# Altium schematic sheet HLib-Comm-Ether_r1.SchDoc(HLib-Comm-Ether_r1)
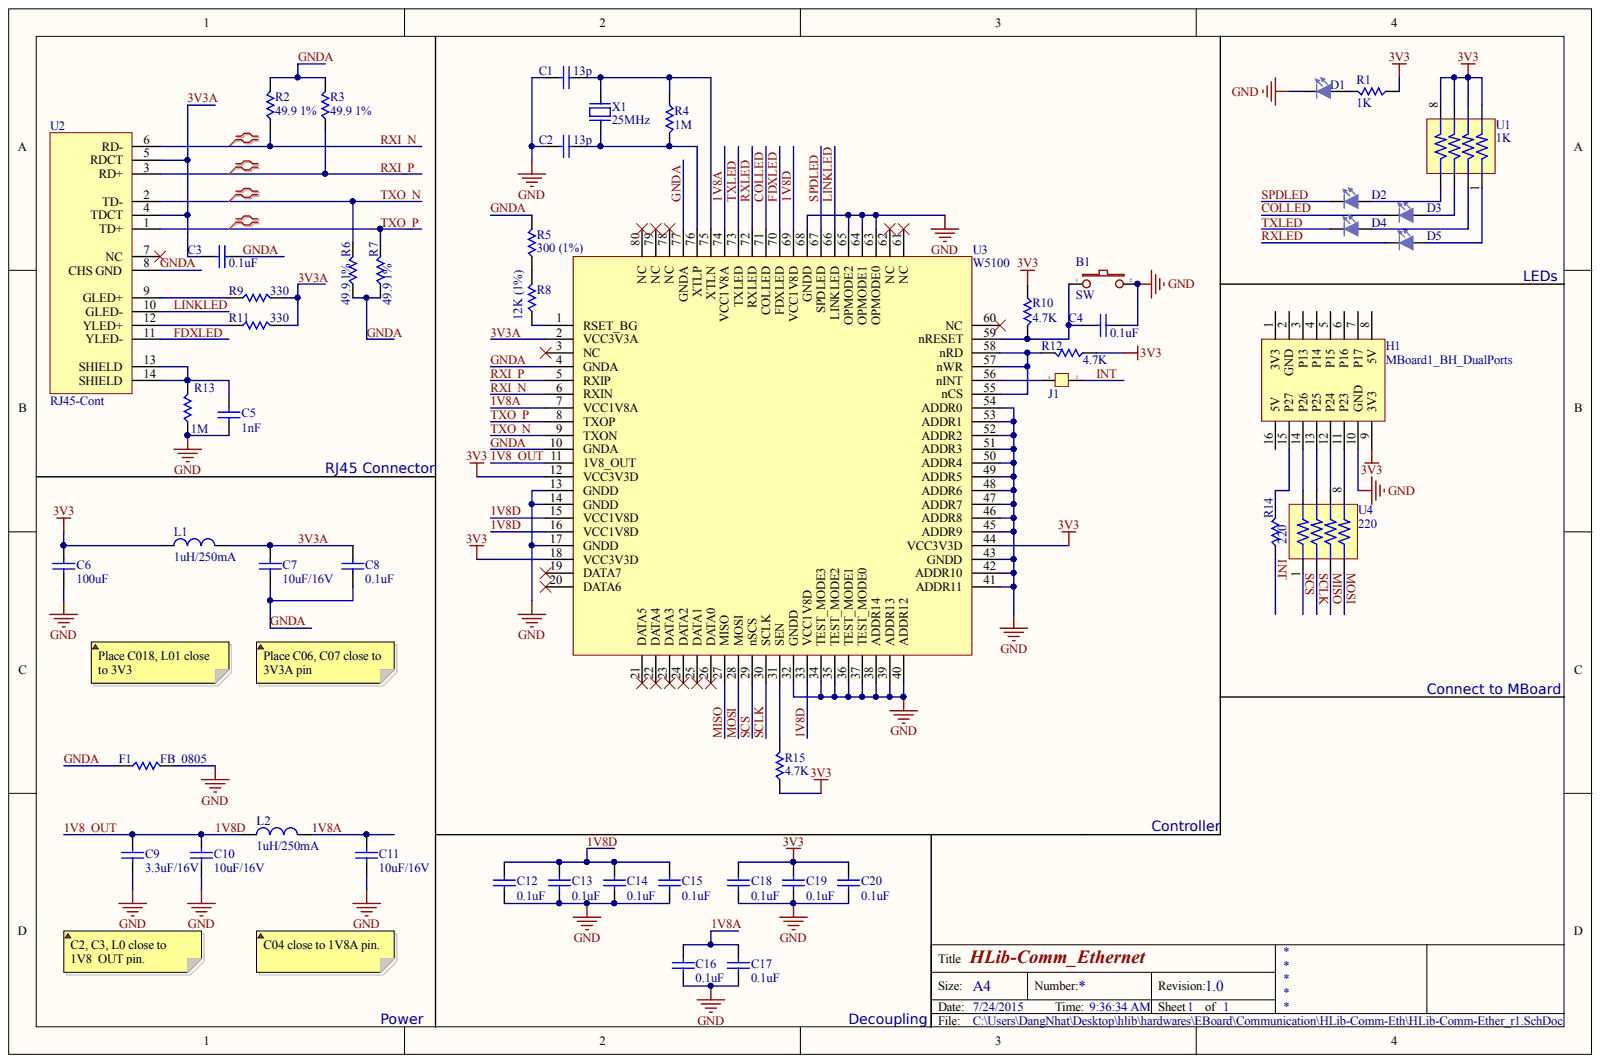
[diagram p0: HLib-Comm-Ether_r1.SchDoc]

## Parts
B1  SW  [SW2SMD]
C1  value 13p  [SM_0805_C_CERAMIC]  (hidden comment: C_Ceramic)
C2  value 13p  [SM_0805_C_CERAMIC]  (hidden comment: C_Ceramic)
C3  value 0.1uF  [SM_0805_C_CERAMIC]  (hidden comment: C_Ceramic)
C4  value 0.1uF  [SM_0805_C_CERAMIC]  (hidden comment: C_Ceramic)
C5  value 1nF  [SM_0805_C_CERAMIC]  (hidden comment: C_Ceramic)
C6  value 100uF  [Cap-Alu-D8]  (hidden comment: C_Ceramic)
C7  value 10uF/16V  [SM_0805_C_CERAMIC]  (hidden comment: C_Ceramic)
C8  value 0.1uF  [SM_0805_C_CERAMIC]  (hidden comment: C_Ceramic)
C9  value 3.3uF/16V  [SM_0805_C_CERAMIC]  (hidden comment: C_Ceramic)
C10  value 10uF/16V  [SM_0805_C_CERAMIC]  (hidden comment: C_Ceramic)
C11  value 10uF/16V  [SM_0805_C_CERAMIC]  (hidden comment: C_Ceramic)
C12  value 0.1uF  [SM_0805_C_CERAMIC]  (hidden comment: C_Ceramic)
C13  value 0.1uF  [SM_0805_C_CERAMIC]  (hidden comment: C_Ceramic)
C14  value 0.1uF  [SM_0805_C_CERAMIC]  (hidden comment: C_Ceramic)
C15  value 0.1uF  [SM_0805_C_CERAMIC]  (hidden comment: C_Ceramic)
C16  value 0.1uF  [SM_0805_C_CERAMIC]  (hidden comment: C_Ceramic)
C17  value 0.1uF  [SM_0805_C_CERAMIC]  (hidden comment: C_Ceramic)
C18  value 0.1uF  [SM_0805_C_CERAMIC]  (hidden comment: C_Ceramic)
C19  value 0.1uF  [SM_0805_C_CERAMIC]  (hidden comment: C_Ceramic)
C20  value 0.1uF  [SM_0805_C_CERAMIC]  (hidden comment: C_Ceramic)
D1  LED  [SM_0805_LED]  (value not drawn)
D2  LED  [SM_0805_LED]  (value not drawn)
D3  LED  [SM_0805_LED]  (value not drawn)
D4  LED  [SM_0805_LED]  (value not drawn)
D5  LED  [SM_0805_LED]  (value not drawn)
F1  value FB_0805  [SM_0805_R]  (hidden comment: R)
H1  MBoard1_BH_DualPorts  [MBoard1_BH_DualPorts]
J1  Jumper 2  [Hed2]  (value not drawn)
L1  1uH/250mA  [SM_0805_R]
L2  1uH/250mA  [SM_0805_R]
R1  R  value 1K  [SM_0805_R]
R2  R  value 49.9 1%  [SM_0805_R]
R3  R  value 49.9 1%  [SM_0805_R]
R4  R  value 1M  [SM_0805_R]
R5  R  value 300 (1%)  [SM_0805_R]
R6  R  value 49.9 1%  [SM_0805_R]
R7  R  value 49.9 1%  [SM_0805_R]
R8  R  value 12K (1%)  [SM_0805_R]
R9  R  value 330  [SM_0805_R]
R10  R  value 4.7K  [SM_0805_R]
R11  R  value 330  [SM_0805_R]
R12  R  value 4.7K  [SM_0805_R]
R13  R  value 1M  [SM_0805_R]
R14  R  value 220  [SM_0805_R]
R15  R  value 4.7K  [SM_0805_R]
U1  1K  [RA4]
U2  RJ45-Cont  [RJ45-Cont]
U3  W5100  [LQFP80]
U4  220  [RA4]
X1  25MHz  [HC49/US-SMD]

## Nets
3V3: C6.1 C18.2 C19.2 C20.2 H1.9(3V3) L1.1 R1.1 R10.1 R12.1 R15.1 U1.2 U1.4 U1.6 U1.8 U3.12(VCC3V3D) U3.18(VCC3V3D) U3.44(VCC3V3D)
GND: B1.2 C1.1 C2.1 C4.1 C5.2 C6.2 C9.1 C10.1 C11.1 C12.1 C13.1 C14.1 C15.1 C16.1 C17.1 C18.1 C19.1 C20.1 D1.2 F1.2 H1.10(GND) R13.1 U3.13(GNDD) U3.14(GNDD) U3.17(GNDD) U3.32(GNDD) U3.34(TEST_MODE3) U3.35(TEST_MODE2) U3.36(TEST_MODE1) U3.37(TEST_MODE0) U3.38(ADDR14) U3.39(ADDR13) U3.40(ADDR12) U3.41(ADDR11) U3.42(ADDR10) U3.43(GNDD) U3.45(ADDR9) U3.46(ADDR8) U3.47(ADDR7) U3.48(ADDR6) U3.49(ADDR5) U3.50(ADDR4) U3.51(ADDR3) U3.52(ADDR2) U3.53(ADDR1) U3.54(ADDR0) U3.63(OPMODE0) U3.64(OPMODE1) U3.65(OPMODE2) U3.68(GNDD)
1V8_OUT: C9.2 C10.2 C12.2 C13.2 C14.2 C15.2 L2.1 U3.11(1V8_OUT) U3.15(VCC1V8D) U3.16(VCC1V8D) U3.33(VCC1V8D) U3.69(VCC1V8D)
1V8A: C11.2 C16.2 C17.2 L2.2 U3.7(VCC1V8A) U3.74(VCC1V8A)
3V3A: C3.2 C7.2 C8.2 L1.2 R9.2 R11.2 U2.4(TDCT) U2.5(RDCT) U3.2(VCC3V3A)
COLLED: D3.2 U3.71(COLLED)
FDXLED: U2.11(YLED-) U3.70(FDXLED)
GNDA: C3.1 C7.1 C8.1 F1.1 R2.2 R3.2 R5.2 R6.1 R7.1 U2.8(CHS_GND) U3.4(GNDA) U3.10(GNDA) U3.77(GNDA)
INT: J1.2 R14.1
LINKLED: U2.10(GLED-) U3.66(LINKLED)
MISO: U3.27(MISO) U4.5
MOSI: U3.28(MOSI) U4.7
RXI_N: R2.1 U2.6(RD-) U3.6(RXIN)
RXI_P: R3.1 U2.3(RD+) U3.5(RXIP)
RXLED: D5.2 U3.72(RXLED)
SCLK: U3.30(SCLK) U4.3
SCS: U3.29(nSCS) U4.1
SPDLED: D2.2 U3.67(SPDLED)
TXLED: D4.2 U3.73(TXLED)
TXO_N: R6.2 U2.2(TD-) U3.9(TXON)
TXO_P: R7.2 U2.1(TD+) U3.8(TXOP)
NetB1_1: B1.1 C4.2 R10.2 U3.59(nRESET)
NetC1_2: C1.2 R4.1 U3.75(XTLN) X1.1
NetC2_2: C2.2 R4.2 U3.76(XTLP) X1.2
NetC5_1: C5.1 R13.2 U2.13(SHIELD) U2.14(SHIELD)
NetD1_1: D1.1 R1.2
NetD2_1: D2.1 U1.7
NetD3_1: D3.1 U1.5
NetD4_1: D4.1 U1.3
NetD5_1: D5.1 U1.1
NetH1_11: H1.11(P23) U4.8
NetH1_12: H1.12(P24) U4.6
NetH1_13: H1.13(P25) U4.4
NetH1_14: H1.14(P26) U4.2
NetH1_15: H1.15(P27) R14.2
NetJ1_1: J1.1 U3.56(nINT)
NetR5_1: R5.1 R8.2
NetR8_1: R8.1 U3.1(RSET_BG)
NetR9_1: R9.1 U2.9(GLED+)
NetR11_1: R11.1 U2.12(YLED+)
NetR12_2: R12.2 U3.55(nCS) U3.57(nWR) U3.58(nRD)
NetR15_2: R15.2 U3.31(SEN)

Not connected: H1 1(3V3) 2(GND) 3(P13) 4(P14) 5(P15) 6(P16) 7(P17) 8(5V) 16(5V); U2 7(NC); U3 3(NC) 19(DATA7) 20(DATA6) 21(DATA5) 22(DATA4) 23(DATA3) 24(DATA2) 25(DATA1) 26(DATA0) 60(NC) 61(NC) 62(NC) 78(NC) 79(NC) 80(NC)

## Library data of the parts
B1 = SW2: Switch button 2 pin; Value: SW chip 2 pin; Component Kind: Standard; Library Name: syhaunguyen.SchLib
C1-C20 = C_Ceramic: Non-polarity ceramic capacitor; Component Kind: Standard; LatestRevisionDate: 17-Jul-2002; LatestRevisionNote: Re-released for DXP Platform.; Library Name: HLib_discrete_sch.SchLib; PackageDescription: Radial Cap, Thru-Hole; 2 Leads; 0.3 in Pin Spacing; PackageReference: RAD-0.3; Published: 8-Jun-2000; Publisher: Altium Limited
F1 R1 R3-R15 = R: Resistor; Component Kind: Standard; LatestRevisionDate: 29-May-2009; LatestRevisionNote: IPC-7351 Footprint Added.; Library Name: HLib_discrete_sch.SchLib; PackageDescription: Chip Resistor; PackageReference: J1-0603; Published: 8-Jun-2000; Publisher: Altium Limited
J1 = JP 2: Value: JP 2; Component Kind: Standard; Description: Jumper 2; Library Name: VioletofSun.SchLib
L1 L2 = L1: Inductor; Value: 150 mH; Component Kind: Standard; Library Name: VioletofSun.SchLib
R2 = R: Resistor; Value: 49.9 1%; Component Kind: Standard; LatestRevisionDate: 29-May-2009; LatestRevisionNote: IPC-7351 Footprint Added.; Library Name: HLib_discrete_sch.SchLib; PackageDescription: Chip Resistor; PackageReference: J1-0603; Published: 8-Jun-2000; Publisher: Altium Limited
U1 U4 = RA4: Component Kind: Standard; Library Name: Nhat_Lib.SchLib
X1 = XT2: Crystal For all Crystal 2pin.; Value: 4Mhz; Component Kind: Standard; Library Name: VioletofSun.SchLib
D1-D5 = LED: Component Kind: Standard; Description: LED; LatestRevisionDate: 08-Jul-2005; LatestRevisionNote: Mech Layer 1 removed.; Library Name: HLib_discrete_sch.SchLib; PackageDescription: LED; 2 Leads; PackageReference: LED-1; Published: 8-Jun-2000; Publisher: Altium Limited
H1 = MBoard1_BH_DualPorts: Component Kind: Standard; Library Name: Nhat_Lib.SchLib
U2 = RJ45-Cont: Component Kind: Standard; Library Name: Nhat_Lib.SchLib
U3 = W5100: Component Kind: Standard; Library Name: Nhat_Lib.SchLib
